# Altium schematic sheet 遥控器_V1_0_B.SchDoc(遥控器_V1_0_B)
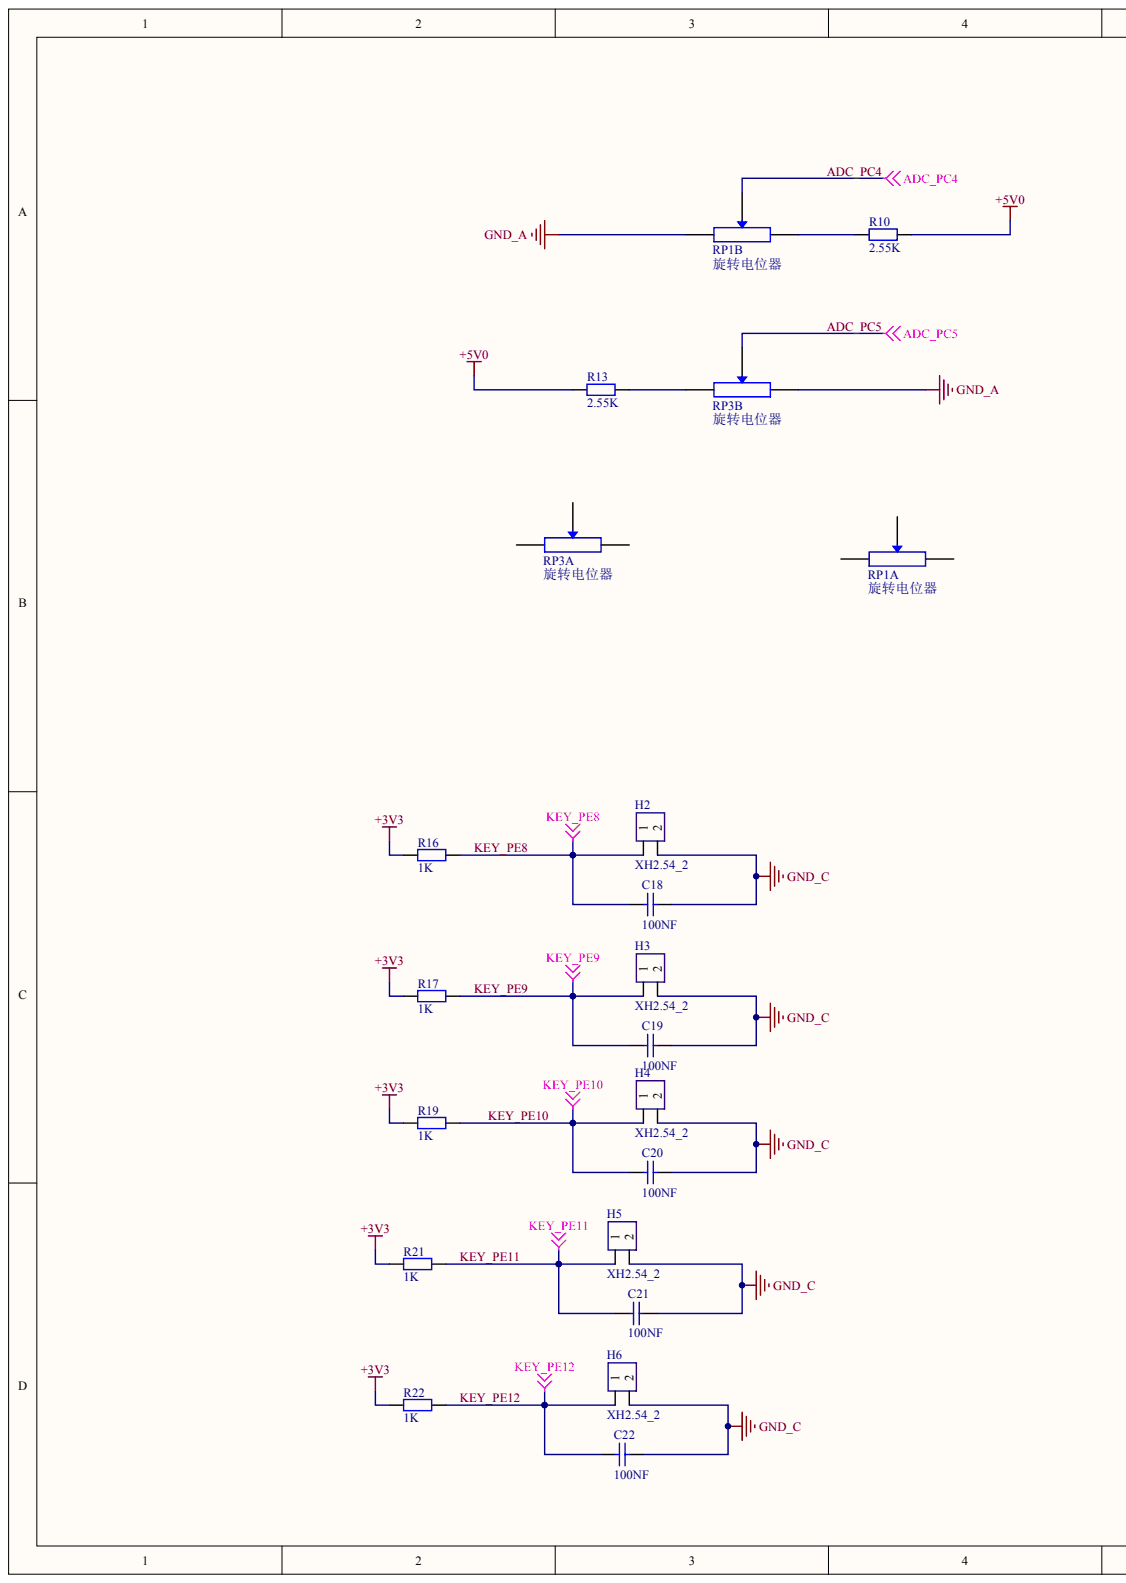
[diagram p0: 遥控器_V1_0_B.SchDoc, left]
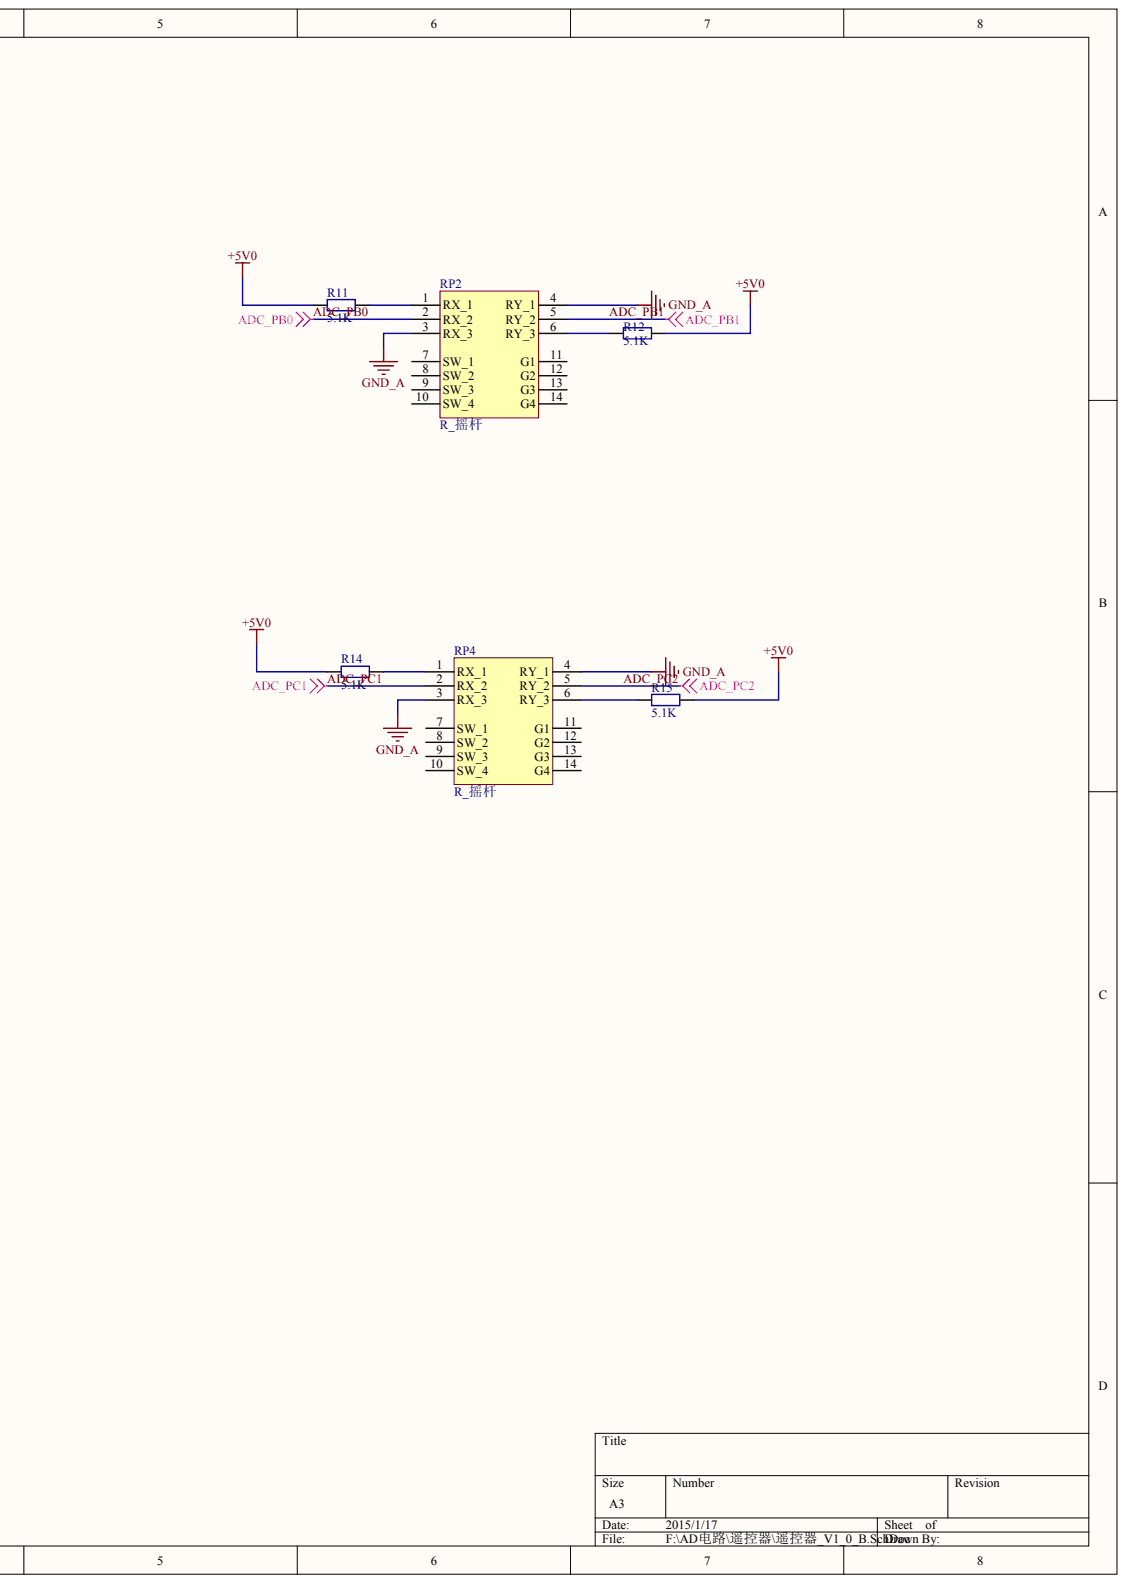
[diagram p1: 遥控器_V1_0_B.SchDoc, right]

## Parts
C18  100NF
C19  100NF
C20  100NF
C21  100NF
C22  100NF
H2  XH2.54_2
H3  XH2.54_2
H4  XH2.54_2
H5  XH2.54_2
H6  XH2.54_2
R10  2.55K
R11  5.1K
R12  5.1K
R13  2.55K
R14  5.1K
R15  5.1K
R16  1K
R17  1K
R19  1K
R21  1K
R22  1K
RP1  旋转电位器  (parts A,B)
RP2  R_摇杆
RP3  旋转电位器  (parts A,B)
RP4  R_摇杆

## Nets
+3V3: R16.1 R17.1 R19.1 R21.1 R22.1
+5V0: R10.2 R11.1 R12.2 R13.2 R14.1 R15.2
GND_A: RP1.4 RP2.3(RX_3) RP2.4(RY_1) RP3.4 RP4.3(RX_3) RP4.4(RY_1)
GND_C: C18.1 C19.1 C20.1 C21.1 C22.1 H2.2 H3.2 H4.2 H5.2 H6.2
ADC_PB0: RP2.2(RX_2)
ADC_PB1: RP2.5(RY_2)
ADC_PC1: RP4.2(RX_2)
ADC_PC2: RP4.5(RY_2)
ADC_PC4: RP1.5
ADC_PC5: RP3.5
KEY_PE8: C18.2 H2.1 R16.2
KEY_PE9: C19.2 H3.1 R17.2
KEY_PE10: C20.2 H4.1 R19.2
KEY_PE11: C21.2 H5.1 R21.2
KEY_PE12: C22.2 H6.1 R22.2
NetR10_1: R10.1 RP1.6
NetR11_2: R11.2 RP2.1(RX_1)
NetR12_1: R12.1 RP2.6(RY_3)
NetR13_1: R13.1 RP3.6
NetR14_2: R14.2 RP4.1(RX_1)
NetR15_1: R15.1 RP4.6(RY_3)

Not connected: RP1 1 2 3; RP2 7(SW_1) 8(SW_2) 9(SW_3) 10(SW_4) 11(G1) 12(G2) 13(G3) 14(G4); RP3 1 2 3; RP4 7(SW_1) 8(SW_2) 9(SW_3) 10(SW_4) 11(G1) 12(G2) 13(G3) 14(G4)

## Library data of the parts
R10-R15 = (no symbol name): value: 1K
C18-C22 H2-H6 R16 R17 R19 R21 R22 RP1-RP4 = (no symbol name)
  C18-C22: value: 100NF
  H2-H6: LatestRevisionDate: 17-Jul-2002; LatestRevisionNote: Re-released for DXP Platform.; Publisher: Altium Limited
  R16 R17 R19 R21 R22: value: 1K
